# Altium schematic sheet Power Supply.SchDoc
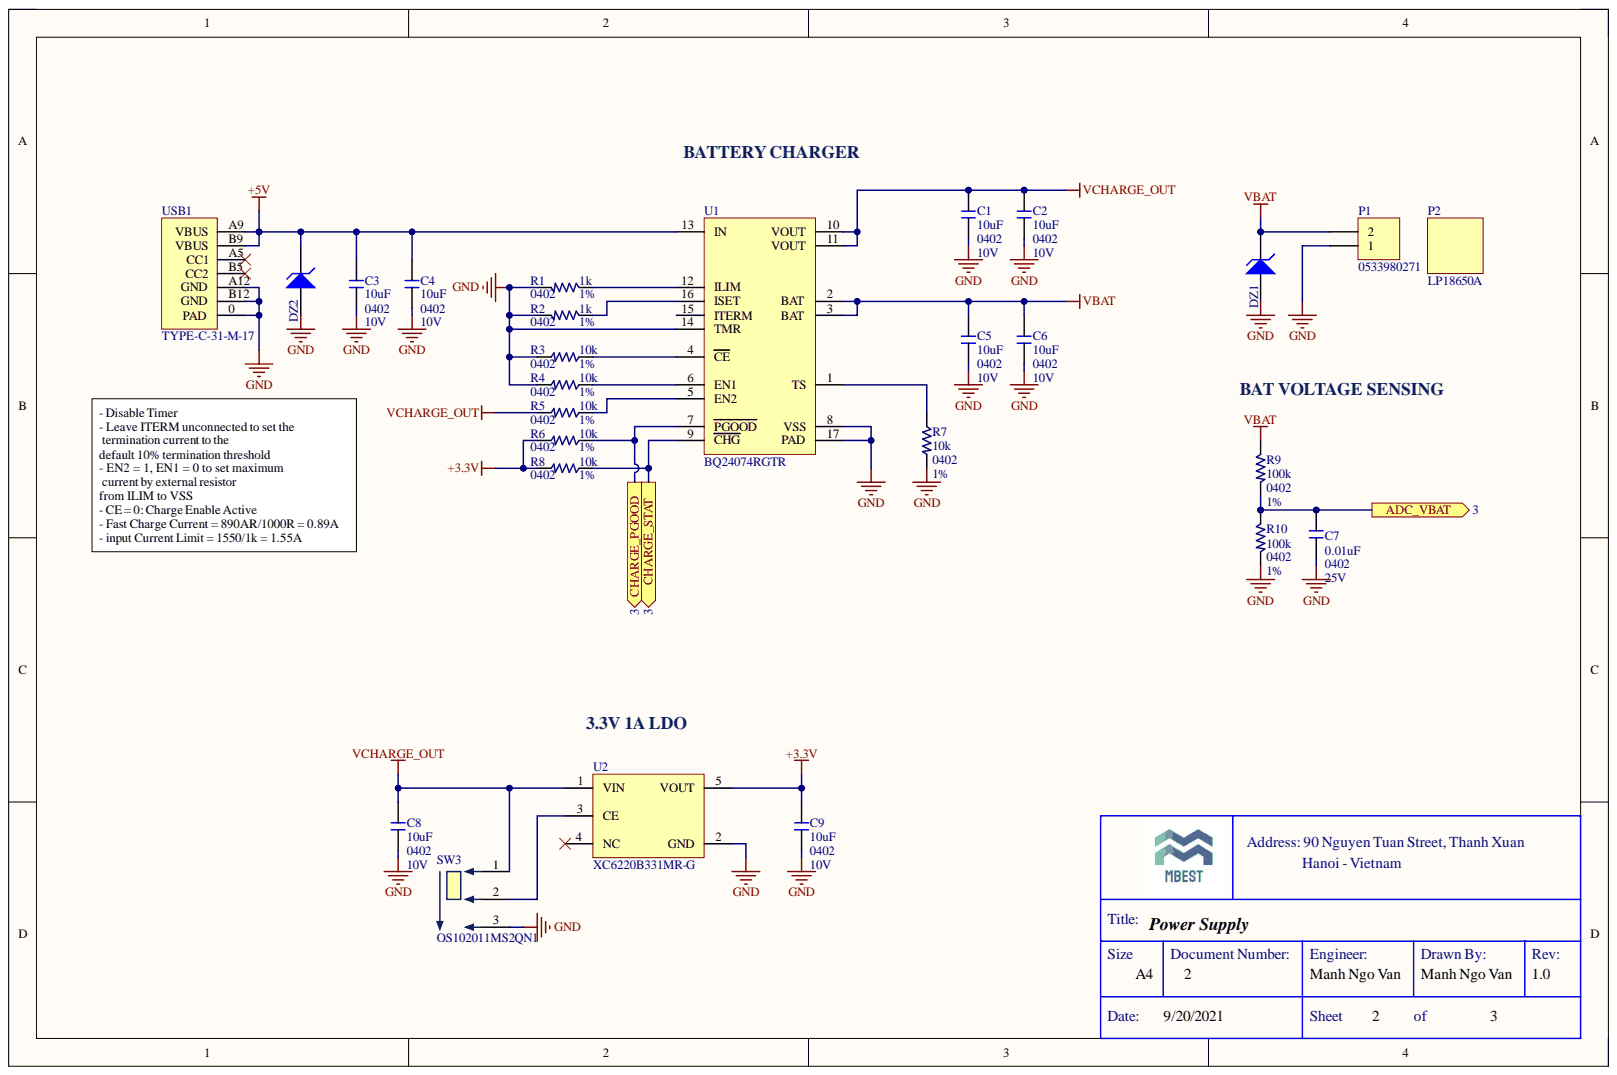
[diagram p0: Power Supply.SchDoc]

## Parts
C1  10uF  Case Code (Imperial): 0402  Voltage Rating: 10V  [C0402M]
C2  10uF  Case Code (Imperial): 0402  Voltage Rating: 10V  [C0402M]
C3  10uF  Case Code (Imperial): 0402  Voltage Rating: 10V  [C0402M]
C4  10uF  Case Code (Imperial): 0402  Voltage Rating: 10V  [C0402M]
C5  10uF  Case Code (Imperial): 0402  Voltage Rating: 10V  [C0402M]
C6  10uF  Case Code (Imperial): 0402  Voltage Rating: 10V  [C0402M]
C7  0.01uF  Case Code (Imperial): 0402  Voltage Rating: 25V  [C0402M]
C8  10uF  Case Code (Imperial): 0402  Voltage Rating: 10V  [C0402M]
C9  10uF  Case Code (Imperial): 0402  Voltage Rating: 10V  [C0402M]
DZ1  TPD1E10B06DPYR  [R0402M]  (value not drawn)
DZ2  TPD1E10B06DPYR  [R0402M]  (value not drawn)
P1  0533980271  [0533980271]  (no BOM)
P2  LP18650A  (mechanical)
R1  1k  Case Code (Imperial): 0402  Resistance Tolerance: 1%  [R0402M]
R2  1k  Case Code (Imperial): 0402  Resistance Tolerance: 1%  [R0402M]
R3  10k  Case Code (Imperial): 0402  Tolerance: 1%  [R0402M]
R4  10k  Case Code (Imperial): 0402  Tolerance: 1%  [R0402M]
R5  10k  Case Code (Imperial): 0402  Tolerance: 1%  [R0402M]
R6  10k  Case Code (Imperial): 0402  Tolerance: 1%  [R0402M]
R7  10k  Case Code (Imperial): 0402  Tolerance: 1%  [R0402M]
R8  10k  Case Code (Imperial): 0402  Tolerance: 1%  [R0402M]
R9  100k  Case Code (Imperial): 0402  Tolerance: 1%  [R0402M]
R10  100k  Case Code (Imperial): 0402  Tolerance: 1%  [R0402M]
SW3  OS102011MS2QN1  [OS102011MS2QN1]
U1  BQ24074RGTR  [QFN50P300X300X100_HS-17N]
U2  XC6220B331MR-G  [SOT95P280X145-5NP]
USB1  TYPE-C-31-M-17  [TYPE-C-31-M-17]

## Ports
ADC_VBAT, CHARGE_PGOOD, CHARGE_STAT

## Nets
+3.3V: C9.2 R6.1 R8.1 U2.5(VOUT)
+5V: C3.2 C4.2 DZ2.2 U1.13(IN) USB1.A9(VBUS) USB1.B9(VBUS)
GND: C1.1 C2.1 C3.1 C4.1 C5.1 C6.1 C7.1 C8.1 C9.1 DZ1.1 DZ2.1 P1.1 R1.1 R2.1 R3.1 R4.1 R7.1 R10.1 SW3.3 U1.8(VSS) U1.14(TMR) U1.17(PAD) U2.2(GND) USB1.0(PAD) USB1.A12(GND) USB1.B12(GND)
VBAT: C5.2 C6.2 DZ1.2 P1.2 R9.2 U1.2(BAT) U1.3(BAT)
VCHARGE_OUT: C1.2 C2.2 C8.2 R5.1 SW3.1 U1.10(VOUT) U1.11(VOUT) U2.1(VIN)
ADC_VBAT: C7.2 R9.1 R10.2 ; port ADC_VBAT
CHARGE_PGOOD: R6.2 U1.7(PGOOD) ; port CHARGE_PGOOD
CHARGE_STAT: R8.2 U1.9(CHG) ; port CHARGE_STAT
NetR1_2: R1.2 U1.12(ILIM)
NetR2_2: R2.2 U1.16(ISET)
NetR3_2: R3.2 U1.4(CE)
NetR4_2: R4.2 U1.6(EN1)
NetR5_2: R5.2 U1.5(EN2)
NetR7_2: R7.2 U1.1(TS)
NetSW3_2: SW3.2 U2.3(CE)

Not connected: U1 15(ITERM); U2 4(NC); USB1 A5(CC1) B5(CC2)

## Library data of the parts
C1-C6 C8 C9 = CAP_CER: CAP CER 10UF 10V X5R 0402; Manufacturer 1: Samsung; Manufacturer Part Number 1: CL05A106MP8NUB8; Mouting Technology: SMT; Tolerance: 20%; Capacitance: 10uF; Case Code (Metric): 1005; Case/Package: 0402; Category: Ceramic Capacitors; Component Kind: Standard; ComponentLink1Description: http://www.samsungsem.com/kr/support/product-search/mlcc/CL05A106MP8NUB8.jsp?src-supplier=Digi-Key; ComponentLink1URL: http://www.samsungsem.com/kr/support/product-search/mlcc/CL05A106MP8NUB8.jsp?src-supplier=Digi-Key; Length: 0.039inch; Library Name: CommonLib.SchLib; Max Operating Temperature: 85°C; Min Operating Temperature: -55°C; Mount: Surface Mount; Packaging: Tape and Reel; Pin: 2; Pricing 1: 1=0.37000, 10=0.25800, 50=0.18040, 100=0.15460, 500=0.11598, 1000=0.09793, 2500=0.09278 (USD); RoHS: Yes; RoHS Compliant: Yes; Stock 1: 5372550; Supplier 1: Digi-Key; Supplier Part Number 1: 1276-6830-6-ND; Thickness: 0.035inch; Width: 0.02inch
C7 = CAP_CER: CAP CER 10000PF 25V X7R 0402; Manufacturer: Samsung; Manufacturer Part Number: CL05B103KA5NNNC; Capacitance: 10nF; Tolerance: 10%; Case Code (Metric): 1005; Case/Package: 0402; Component Kind: Standard; ComponentLink1Description: https://datasheet.ciiva.com/11586/upy-gphc-x7r-6-3v-to-50v-8-11586326.pdf?src-supplier=Avnet; ComponentLink1URL: https://datasheet.ciiva.com/11586/upy-gphc-x7r-6-3v-to-50v-8-11586326.pdf?src-supplier=Avnet; ComponentLink2Description: https://datasheet.ciiva.com/17434/upy-gphc-x7r-6-3v-to-50v-8-17434572.pdf?src-supplier=Avnet+Europe; ComponentLink2URL: https://datasheet.ciiva.com/17434/upy-gphc-x7r-6-3v-to-50v-8-17434572.pdf?src-supplier=Avnet+Europe; ComponentLink3Description: https://datasheet.ciiva.com/15195/getdatasheetpartid-358612-15195635.pdf?src-supplier=Verical; ComponentLink3URL: https://datasheet.ciiva.com/15195/getdatasheetpartid-358612-15195635.pdf?src-supplier=Verical; ComponentLink4Description: https://datasheet.ciiva.com/16740/cl05b104kq5nnnc-16740683.pdf?src-supplier=Future+Electronics; ComponentLink4URL: https://datasheet.ciiva.com/16740/cl05b104kq5nnnc-16740683.pdf?src-supplier=Future+Electronics; Contact Plating: Tin; Depth: 0.5mm; Dielectric: X7R; Height: 0.5mm; Length: 1mm; Library Name: CommonLib.SchLib; Max Operating Temperature: 125°C; Min Operating Temperature: -55°C; Mount: Surface Mount; Package Quantity: 1; Packaging: Tape and Reel; Pricing 1: 1 = 0.10000, 10 = 0.01800, 50 = 0.00960, 100 = 0.00780, 500 = 0.00558, 1000 = 0.00439, 2500 = 0.00399, 5000 = 0.00367 (USD); Radiation Hardening: No; RoHS: Yes; Stock 1: 2714388; Supplier 1: Digi-Key; Supplier Part Number 1: 1276-1057-1-ND; Thickness: 0.022inch; Width: 0.02inch
DZ1 DZ2 = D Zener: TVS DIODE 5.5V 14V 2X1SON; Manufacturer: Texas Instruments; Breakdown Voltage: 6V; Capacitance: 12pF; Direction: Bidirectional; Element Configuration: Single; ESD Protection: Yes; Interface: GPIO; Leakage Current: 100nA; Number of Bidirectional Channels: 1; Peak Pulse Current: 5A; Peak Pulse Power: 90W; Power Line Protection: No; Test Current: 1mA; Case/Package: 0402; Clamping Voltage: 14V; Component Kind: Standard; ComponentLink1Description: https://datasheet.ciiva.com/26005/sllseb1b-26005840.pdf?src-supplier=Avnet+Europe; ComponentLink1URL: https://datasheet.ciiva.com/26005/sllseb1b-26005840.pdf?src-supplier=Avnet+Europe; ComponentLink2Description: https://datasheet.ciiva.com/46384/1877100-46384054.pdf?src-supplier=Element14; ComponentLink2URL: https://datasheet.ciiva.com/46384/1877100-46384054.pdf?src-supplier=Element14; ComponentLink3Description: https://datasheet.ciiva.com/14195/tpd1e10b06-14195178.pdf?src-supplier=Avnet; ComponentLink3URL: https://datasheet.ciiva.com/14195/tpd1e10b06-14195178.pdf?src-supplier=Avnet; ComponentLink4Description: https://datasheet.ciiva.com/22691/1877100-22691786.pdf?src-supplier=Element14; ComponentLink4URL: https://datasheet.ciiva.com/22691/1877100-22691786.pdf?src-supplier=Element14; ComponentLink5Description: https://datasheet.ciiva.com/2961/tpd1e10b06-2961245.pdf?src-supplier=Digi-Key; ComponentLink5URL: https://datasheet.ciiva.com/2961/tpd1e10b06-2961245.pdf?src-supplier=Digi-Key; ComponentLink6Description: https://datasheet.ciiva.com/2961/tpd1e10b06-2961246.pdf?src-supplier=Digi-Key; ComponentLink6URL: https://datasheet.ciiva.com/2961/tpd1e10b06-2961246.pdf?src-supplier=Digi-Key; ComponentLink7Description: https://datasheet.ciiva.com/6574/tpd1e10b06-6574654.pdf?src-supplier=Digi-Key; ComponentLink7URL: https://datasheet.ciiva.com/6574/tpd1e10b06-6574654.pdf?src-supplier=Digi-Key; Contact Plating: Gold; Height: 0.35mm; Lead Free: Lead Free; Length: 1.1mm; Library Name: CommonLib.SchLib; Manufacturer Part Number: TPD1E10B06DPYR; Max Operating Temperature: 125°C; Max Reverse Leakage Current: 100nA; Min Breakdown Voltage: 6V; Min Operating Temperature: -40°C; Number of Channels: 1; Number of Pins: 2; Package Quantity: 1; Packaging: Tape and Reel; Pricing 1: 1 = 0.45000, 10 = 0.29400, 100 = 0.16380, 500 = 0.10920, 1000 = 0.07560, 5000 = 0.07350 (USD); Radiation Hardening: No; REACH SVHC: No SVHC; Resistor Type: Zener; Reverse Standoff Voltage: 5.5V; RoHS: Yes; Signal Integrity: D Zener; Simulation: ZENER; Stock 1: 555750; Supplier 1: Digi-Key; Supplier Part Number 1: 296-30406-1-ND; Width: 0.7mm; Working Voltage: 5.5V
P1 = Header 2: CONN HEADER SMD 2POS 1.25MM; Manufacturer 1: Molex; Manufacturer Part Number 1: 53398-0271; Contact Resistance: 20mR; Current Rating: 1A; Gender: Male; Glow Wire Compliant: Non-compliant; Insulation Resistance: 100MR; Number of Circuits: 2; Voltage Rating: 125V; Category: Headers and Wire Housings; Color: Natural; ComponentLink1Description: https://datasheet.ciiva.com/7167/1626130-7167520.pdf?src-supplier=Newark; ComponentLink1URL: https://datasheet.ciiva.com/7167/1626130-7167520.pdf?src-supplier=Newark; ComponentLink2Description: https://datasheet.ciiva.com/7920/1841150-7920834.pdf?src-supplier=Element14; ComponentLink2URL: https://datasheet.ciiva.com/7920/1841150-7920834.pdf?src-supplier=Element14; ComponentLink3Description: https://datasheet.ciiva.com/14156/gf116833-116846-e-14156243.pdf?src-supplier=Distrelec; ComponentLink3URL: https://datasheet.ciiva.com/14156/gf116833-116846-e-14156243.pdf?src-supplier=Distrelec; ComponentLink4Description: https://datasheet.ciiva.com/36178/1932619-36178944.pdf?src-supplier=Jameco; ComponentLink4URL: https://datasheet.ciiva.com/36178/1932619-36178944.pdf?src-supplier=Jameco; ComponentLink5Description: https://datasheet.ciiva.com/28523/1759360-28523372.pdf?src-supplier=Element14; ComponentLink5URL: https://datasheet.ciiva.com/28523/1759360-28523372.pdf?src-supplier=Element14; ComponentLink6Description: https://datasheet.ciiva.com/9468/0533980271-pcb-headers-9468512.pdf?src-supplier=Avnet; ComponentLink6URL: https://datasheet.ciiva.com/9468/0533980271-pcb-headers-9468512.pdf?src-supplier=Avnet; ComponentLink7Description: https://datasheet.ciiva.com/30229/225140533980271-pcb-headers-30229043.pdf?src-supplier=Onlinecomponents.com; ComponentLink7URL: https://datasheet.ciiva.com/30229/225140533980271-pcb-headers-30229043.pdf?src-supplier=Onlinecomponents.com; ComponentLink8Description: https://datasheet.ciiva.com/5150/0533980271-pcb-headers-146564-5150549.pdf?src-supplier=Mouser; ComponentLink8URL: https://datasheet.ciiva.com/5150/0533980271-pcb-headers-146564-5150549.pdf?src-supplier=Mouser; ComponentLink9Description: https://datasheet.ciiva.com/11746/0900766b80ab946a-11746463.pdf?src-supplier=RSComponents; ComponentLink9URL: https://datasheet.ciiva.com/11746/0900766b80ab946a-11746463.pdf?src-supplier=RSComponents; ComponentLink10Description: https://datasheet.ciiva.com/22707/1867379-22707654.pdf?src-supplier=Element14; ComponentLink10URL: https://datasheet.ciiva.com/22707/1867379-22707654.pdf?src-supplier=Element14; ComponentLink11Description: https://datasheet.ciiva.com/22683/1626130-22683563.pdf?src-supplier=Element14; ComponentLink11URL: https://datasheet.ciiva.com/22683/1626130-22683563.pdf?src-supplier=Element14; ComponentLink12Description: https://datasheet.ciiva.com/16658/0533980271-pcb-headers-16658429.pdf?src-supplier=Avnet+Europe; ComponentLink12URL: https://datasheet.ciiva.com/16658/0533980271-pcb-headers-16658429.pdf?src-supplier=Avnet+Europe; ComponentLink13Description: https://datasheet.ciiva.com/18454/70090670-18454279.pdf?src-supplier=Allied+Electronics; ComponentLink13URL: https://datasheet.ciiva.com/18454/70090670-18454279.pdf?src-supplier=Allied+Electronics; Contact Material: Bronze,Brass,Tin; Contact Plating: Tin; Contact Type: Blade Pin; Depth: 4.2mm; Flammability Rating: UL94 V-0; Housing Color: Natural; Housing Material: Nylon; LatestRevisionDate: 17-Jul-2002; LatestRevisionNote: Re-released for DXP Platform.; Lead Free: Lead Free; Library Name: Miscellaneous Connectors.IntLib; Max Operating Temperature: 85°C; Min Operating Temperature: -40°C; Mount: Surface Mount; Number of Contacts: 2; Number of Positions: 2; Number of Rows: 1; Orientation: Vertical; Package Quantity: 1000; Packaging: Tape and Reel; Pitch: 1.25mm; Pricing 1: 1=0.53000, 10=0.44900, 100=0.34940 (USD); Radiation Hardening: No; REACH SVHC: Unknown; RoHS: Yes; RoHS Compliant: Yes; Series: PicoBlade; Signal Integrity: Connector; Stock 1: 417; Supplier 1: Digi-Key; Supplier Part Number 1: WM7606CT-ND; Termination: Solder; Voltage Rating (AC): 125V; Voltage Rating (DC): 125V; Working Voltage: 125V; Publisher: Altium Limited
P2 = ADDITION: 3.7V Rechargeable Lithium-ion Polymer Battery LP18650A+ 3500mAh With PCM and JST Connector; Manufacturer 1: Dongguan Juhe New Energy; ComponentLink1Description: Link; ComponentLink1URL: https://www.li-polymer-battery.com/3-7v-rechargeable-lithium-ion-polymer-battery-lp18650a-3500mah-with-pcm-and-jst-connector/page/5/?et_blog; Library Name: CommonLib.SchLib; Manufacturer Part Number 1: LP18650A
R1 R2 = RES_SMD: RES SMD 1K OHM 1% 1/16W 0402; Manufacturer 1: Yageo; Manufacturer Part Number 1: RC0402FR-071KL; Max Power Dissipation: 63mW; Mouting Technology: SMT; Power Rating: 62.5mW; Resistance: 1kR; Temperature Coefficient: 100ppm/°C; Voltage Rating: 50V; Case Code (Metric): 1005; Case/Package: 0402; Category: Chip SMD Resistors; Component Kind: Standard; ComponentLink1Description: https://datasheet.ciiva.com/11819/0900766b80c66297-11819477.pdf?src-supplier=RSComponents; ComponentLink1URL: https://datasheet.ciiva.com/11819/0900766b80c66297-11819477.pdf?src-supplier=RSComponents; ComponentLink2Description: https://datasheet.ciiva.com/7983/1018594-7983502.pdf?src-supplier=Element14; ComponentLink2URL: https://datasheet.ciiva.com/7983/1018594-7983502.pdf?src-supplier=Element14; ComponentLink3Description: https://datasheet.ciiva.com/22747/1018594-22747513.pdf?src-supplier=Element14; ComponentLink3URL: https://datasheet.ciiva.com/22747/1018594-22747513.pdf?src-supplier=Element14; ComponentLink4Description: https://datasheet.ciiva.com/12666/pyu-rc0402-51-rohs-l-6-r-12666741.pdf?src-supplier=Mouser; ComponentLink4URL: https://datasheet.ciiva.com/12666/pyu-rc0402-51-rohs-l-6-r-12666741.pdf?src-supplier=Mouser; ComponentLink5Description: https://datasheet.ciiva.com/7849/1018594-7849869.pdf?src-supplier=Element14; ComponentLink5URL: https://datasheet.ciiva.com/7849/1018594-7849869.pdf?src-supplier=Element14; ComponentLink6Description: https://datasheet.ciiva.com/7849/1018594-7849871.pdf?src-supplier=Element14; ComponentLink6URL: https://datasheet.ciiva.com/7849/1018594-7849871.pdf?src-supplier=Element14; ComponentLink7Description: https://datasheet.ciiva.com/17063/pyu-rc0402-51-rohs-l-6-r-17063193.pdf?src-supplier=Future+Electronics; ComponentLink7URL: https://datasheet.ciiva.com/17063/pyu-rc0402-51-rohs-l-6-r-17063193.pdf?src-supplier=Future+Electronics; Construction: Rectangular; Depth: 0.5mm; Features: Moisture Resistant; Height: 0.32mm; Lead Free: Lead Free; Length: 1mm; Library Name: CommonLib.SchLib; Max Operating Temperature: 155°C; Military Standard: Not; Min Operating Temperature: -55°C; Number of Pins: 2; Number of Terminations: 2; Packaging: Tape and Reel; Pin: 2; Pricing 1: 1=0.10000, 10=0.01600, 100=0.00660, 1000=0.00299, 2500=0.00259, 5000=0.00214 (USD); REACH SVHC: Unknown; Resistor Type: Thick Film; RoHS: Yes; RoHS Compliant: Yes; Series: RC; Size Code: 0402; Supplier 1: Digi-Key; Supplier Part Number 1: 311-1.00KLRCT-ND; Technology: THICK FILM; Termination: SMD/SMT; Tolerance: 1%; Voltage Rating (DC): 50V; Width: 0.5mm
R3-R8 = RES_SMD: RES SMD 10K OHM 1% 1/16W 0402; Manufacturer: Yageo; Manufacturer Part Number: RC0402FR-0710KL; Power Rating: 62.5mW; Resistance: 10kR; Temperature Coefficient: 100ppm/°C; Voltage Rating: 50V; Case Code (Metric): 1005; Case/Package: 0402; Component Kind: Standard; ComponentLink1Description: https://datasheet.ciiva.com/7660/38568-7660787.pdf?src-supplier=Element14; ComponentLink1URL: https://datasheet.ciiva.com/7660/38568-7660787.pdf?src-supplier=Element14; ComponentLink2Description: https://datasheet.ciiva.com/7849/1784430-7849813.pdf?src-supplier=Element14; ComponentLink2URL: https://datasheet.ciiva.com/7849/1784430-7849813.pdf?src-supplier=Element14; ComponentLink3Description: https://datasheet.ciiva.com/7849/1686128-7849814.pdf?src-supplier=Element14; ComponentLink3URL: https://datasheet.ciiva.com/7849/1686128-7849814.pdf?src-supplier=Element14; ComponentLink4Description: https://datasheet.ciiva.com/7849/1784430-7849815.pdf?src-supplier=Element14; ComponentLink4URL: https://datasheet.ciiva.com/7849/1784430-7849815.pdf?src-supplier=Element14; ComponentLink5Description: https://datasheet.ciiva.com/22747/1018594-22747512.pdf?src-supplier=Element14; ComponentLink5URL: https://datasheet.ciiva.com/22747/1018594-22747512.pdf?src-supplier=Element14; ComponentLink6Description: https://datasheet.ciiva.com/12667/pyu-rc0402-51-rohs-l-6-r-12667067.pdf?src-supplier=Mouser; ComponentLink6URL: https://datasheet.ciiva.com/12667/pyu-rc0402-51-rohs-l-6-r-12667067.pdf?src-supplier=Mouser; ComponentLink7Description: https://datasheet.ciiva.com/7983/1018594-7983486.pdf?src-supplier=Element14; ComponentLink7URL: https://datasheet.ciiva.com/7983/1018594-7983486.pdf?src-supplier=Element14; Composition: Thick Film; Depth: 0.5mm; Height: 0.32mm; Lead Free: Lead Free; Lead Pitch: 0.2mm; Length: 1mm; Library Name: CommonLib.SchLib; Max Operating Temperature: 155°C; Military Standard: Not; Min Operating Temperature: -55°C; Number of Pins: 2; Number of Terminations: 2; Packaging: Tape and Reel; Pricing 1: 10000 = 0.001630, 30000 = 0.001440, 50000 = 0.001320, 100000 = 0.001290 (USD); REACH SVHC: No SVHC; RoHS: Yes; Stock 1: 58760000; Supplier 1: Digi-Key; Supplier Part Number 1: 311-10.0KLRTR-ND; Termination: SMD/SMT; Voltage Rating (DC): 50V; Width: 0.5mm; Working Voltage: 50V
R9 R10 = RES_SMD: RES SMD 100K OHM 1% 1/16W 0402; Manufacturer: Yageo; Manufacturer Part Number: RC0402FR-07100KL; Max Power Dissipation: 63mW; Resistance: 100kR; Temperature Coefficient: 100ppm/°C; Voltage Rating: 50V; Case Code (Metric): 1005; Case/Package: 0402; Component Kind: Standard; ComponentLink1Description: https://datasheet.ciiva.com/11808/0900766b80f96399-11808107.pdf?src-supplier=RSComponents; ComponentLink1URL: https://datasheet.ciiva.com/11808/0900766b80f96399-11808107.pdf?src-supplier=RSComponents; ComponentLink2Description: https://datasheet.ciiva.com/7983/443971-7983593.pdf?src-supplier=Element14; ComponentLink2URL: https://datasheet.ciiva.com/7983/443971-7983593.pdf?src-supplier=Element14; ComponentLink3Description: https://datasheet.ciiva.com/46440/1868787-46440889.pdf?src-supplier=Element14; ComponentLink3URL: https://datasheet.ciiva.com/46440/1868787-46440889.pdf?src-supplier=Element14; ComponentLink4Description: https://datasheet.ciiva.com/7746/1738695-7746234.pdf?src-supplier=Element14; ComponentLink4URL: https://datasheet.ciiva.com/7746/1738695-7746234.pdf?src-supplier=Element14; ComponentLink5Description: https://datasheet.ciiva.com/7746/1738695-7746235.pdf?src-supplier=Element14; ComponentLink5URL: https://datasheet.ciiva.com/7746/1738695-7746235.pdf?src-supplier=Element14; ComponentLink6Description: https://datasheet.ciiva.com/22747/443971-22747516.pdf?src-supplier=Element14; ComponentLink6URL: https://datasheet.ciiva.com/22747/443971-22747516.pdf?src-supplier=Element14; ComponentLink7Description: https://datasheet.ciiva.com/7850/1018594-7850133.pdf?src-supplier=Element14; ComponentLink7URL: https://datasheet.ciiva.com/7850/1018594-7850133.pdf?src-supplier=Element14; ComponentLink8Description: https://datasheet.ciiva.com/2491/pyu-r-int-thick-7-2491207.pdf?src-supplier=Digi-Key; ComponentLink8URL: https://datasheet.ciiva.com/2491/pyu-r-int-thick-7-2491207.pdf?src-supplier=Digi-Key; ComponentLink9Description: https://datasheet.ciiva.com/2491/pyu-r-int-thick-7-2491208.pdf?src-supplier=Digi-Key; ComponentLink9URL: https://datasheet.ciiva.com/2491/pyu-r-int-thick-7-2491208.pdf?src-supplier=Digi-Key; ComponentLink10Description: https://datasheet.ciiva.com/2491/pyu-r-int-thick-7-2491209.pdf?src-supplier=Digi-Key; ComponentLink10URL: https://datasheet.ciiva.com/2491/pyu-r-int-thick-7-2491209.pdf?src-supplier=Digi-Key; ComponentLink11Description: https://datasheet.ciiva.com/17052/pyu-rc0402-51-rohs-l-6-r-17052137.pdf?src-supplier=Future+Electronics; ComponentLink11URL: https://datasheet.ciiva.com/17052/pyu-rc0402-51-rohs-l-6-r-17052137.pdf?src-supplier=Future+Electronics; ComponentLink12Description: https://datasheet.ciiva.com/12668/pyu-rc0402-51-rohs-l-6-r-12668218.pdf?src-supplier=Mouser; ComponentLink12URL: https://datasheet.ciiva.com/12668/pyu-rc0402-51-rohs-l-6-r-12668218.pdf?src-supplier=Mouser; ComponentLink13Description: https://datasheet.ciiva.com/46394/1018594-46394941.pdf?src-supplier=Element14; ComponentLink13URL: https://datasheet.ciiva.com/46394/1018594-46394941.pdf?src-supplier=Element14; Depth: 0.5mm; Features: Moisture Resistant; Height: 0.016inch; Lead Free: Lead Free; Length: 1mm; Library Name: BLE1.SchLib; Max Operating Temperature: 155°C; Military Standard: Not; Min Operating Temperature: -55°C; Number of Terminations: 2; Package Quantity: 1; Packaging: Tape and Reel; Power Rating: 63mW; Pricing 1: 1 = 0.10000, 10 = 0.01200, 100 = 0.00480, 1000 = 0.00215, 2500 = 0.00186, 5000 = 0.00154 (USD); REACH SVHC: Unknown; Resistor Type: Thick Film; RoHS: Yes; Stock 1: 3850330; Supplier 1: Digi-Key; Supplier Part Number 1: 311-100KJRCT-ND; Termination: SMD/SMT; Width: 0.02inch
SW3 = JS202011JAQN: Slide; Manufacturer 1: ITT C&K; Actuator Color: Black; Actuator Length: 4mm; Actuator Material: Polyoxymethylene,Acetal,Polyacetal; Actuator Type: Standard; Contact Current Rating: 100mA; Contact Resistance: 20mR; Contact Style: Non-Shorting; Electrical Life: 10000Cycles; Insulation Resistance: 100MR; Max Voltage Rating (DC): 12V; Switch Function: On-On; Switch Travel: 2mm; Throw Configuration: SPDT; Category: Slide Switches; Component Kind: Standard; ComponentLink1Description: https://datasheet.ciiva.com/30466/0900766b81263f61-30466806.pdf?src-supplier=RSComponents; ComponentLink1URL: https://datasheet.ciiva.com/30466/0900766b81263f61-30466806.pdf?src-supplier=RSComponents; ComponentLink2Description: https://datasheet.ciiva.com/16298/os102011ms2qn1-16298526.pdf?src-supplier=Future+Electronics; ComponentLink2URL: https://datasheet.ciiva.com/16298/os102011ms2qn1-16298526.pdf?src-supplier=Future+Electronics; ComponentLink3Description: https://datasheet.ciiva.com/2889/os-19jan15-2889173.pdf?src-supplier=Digi-Key; ComponentLink3URL: https://datasheet.ciiva.com/2889/os-19jan15-2889173.pdf?src-supplier=Digi-Key; ComponentLink4Description: https://datasheet.ciiva.com/1699/os-19jan15-1699479.pdf?src-supplier=Newark; ComponentLink4URL: https://datasheet.ciiva.com/1699/os-19jan15-1699479.pdf?src-supplier=Newark; ComponentLink5Description: https://datasheet.ciiva.com/18147/getdatasheetpartid-320490-18147210.pdf?src-supplier=Verical; ComponentLink5URL: https://datasheet.ciiva.com/18147/getdatasheetpartid-320490-18147210.pdf?src-supplier=Verical; ComponentLink6Description: https://datasheet.ciiva.com/16997/70128245-16997771.pdf?src-supplier=Allied+Electronics; ComponentLink6URL: https://datasheet.ciiva.com/16997/70128245-16997771.pdf?src-supplier=Allied+Electronics; ComponentLink7Description: https://datasheet.ciiva.com/26290/os-11mar13-26290652.pdf?src-supplier=Onlinecomponents.com; ComponentLink7URL: https://datasheet.ciiva.com/26290/os-11mar13-26290652.pdf?src-supplier=Onlinecomponents.com; ComponentLink8Description: https://datasheet.ciiva.com/1532/1683358-1532308.pdf?src-supplier=Element14; ComponentLink8URL: https://datasheet.ciiva.com/1532/1683358-1532308.pdf?src-supplier=Element14; Contact Material: Copper Alloy,Brass,Silver; Contact Plating: Silver,Gold; Current Rating: 100mA; Depth: 4.3mm; Housing Material: Resin; Lead Free: Lead Free; Length: 8.6mm; Manufacturer Part Number 1: OS102011MS2QN1; Max Current Rating: 100mA; Max Operating Temperature: 70°C; Mechanical Life: 10000Cycles; Min Operating Temperature: -30°C; Mount: Through Hole,PCB; Orientation: Vertical; Package Quantity: 300; Packaging: Bulk; Pricing 1: 1=0.51000, 10=0.49300, 25=0.47520, 100=0.41110, 250=0.37452, 500=0.34712, 1000=0.31059, 2500=0.29415, 5000=0.27222 (USD); Radiation Hardening: No; REACH SVHC: No SVHC; RoHS: Yes; RoHS Compliant: Yes; Series: OS; Stock 1: 34195; Supplier 1: Digi-Key; Supplier Part Number 1: CKN9565-ND; Termination: PC Pins; Voltage Rating (DC): 12V; Library Name: Poskast.SchLib
U1 = BQ24074RGTR: IC BATT CHG LI-ION 1CELL 16QFN; Manufacturer 1: Texas Instruments; Battery Chemistry: LITHIUM-ION; Battery Pack Voltage: 4.2V; Fault Protection: Over Voltage,Over Temperature,Short Circuit,Reverse Current; Interface: USB; Max Charge Current: 1.5A; Max Supply Voltage: 10.2V; Min Input Voltage: 4.3V; Min Supply Voltage: 4.35V; Number of Cells: 1; Case/Package: VQFN; Category: Battery Management; Component Kind: Standard; ComponentLink1Description: https://datasheet.ciiva.com/1932/bq24074-1932360.pdf?src-supplier=Digi-Key; ComponentLink1URL: https://datasheet.ciiva.com/1932/bq24074-1932360.pdf?src-supplier=Digi-Key; ComponentLink2Description: https://datasheet.ciiva.com/26811/getdatasheetpartid-357714-26811766.pdf?src-supplier=Verical; ComponentLink2URL: https://datasheet.ciiva.com/26811/getdatasheetpartid-357714-26811766.pdf?src-supplier=Verical; ComponentLink3Description: https://datasheet.ciiva.com/3214/bq24079-3214039.pdf?src-supplier=Avnet; ComponentLink3URL: https://datasheet.ciiva.com/3214/bq24079-3214039.pdf?src-supplier=Avnet; ComponentLink4Description: https://datasheet.ciiva.com/26109/bq24079-26109321.pdf?src-supplier=Avnet+Europe; ComponentLink4URL: https://datasheet.ciiva.com/26109/bq24079-26109321.pdf?src-supplier=Avnet+Europe; Contact Plating: Gold; Lead Free: Lead Free; Library Name: SmartHome.SchLib; Manufacturer Part Number 1: BQ24074RGTR; Max Operating Temperature: 125°C; Min Operating Temperature: -40°C; Number of Pins: 16; Output Current: 1.5A; Output Voltage: 4.2V; Package Quantity: 3000; Packaging: Tape and Reel; Pricing 1: 1=2.29000, 10=2.07000, 50=1.95000, 100=1.66000, 1000=1.13000, 10000=1.06000 (USD); Radiation Hardening: No; RoHS: Yes; RoHS Compliant: Yes; Series: -; Stock 1: 15; Supplier 1: Mouser; Supplier Part Number 1: 595-BQ24074RGTR; Weight: 0.000808oz
U2 = XC6220B331MR-G: IC REG LINEAR 3.3V 1A SOT25; Manufacturer: Torex; Dropout Voltage: 655mV; Max Input Voltage: 6V; Min Current Limit: 1.005A; Min Input Voltage: 1.6V; Number of Regulators: 1; Output Type: Fixed; Case/Package: SOT-25; Component Kind: Standard; ComponentLink1Description: https://datasheet.ciiva.com/2040/xc6220-2040200.pdf?src-supplier=Digi-Key; ComponentLink1URL: https://datasheet.ciiva.com/2040/xc6220-2040200.pdf?src-supplier=Digi-Key; ComponentLink2Description: https://datasheet.ciiva.com/2040/xc6220-2040201.pdf?src-supplier=Digi-Key; ComponentLink2URL: https://datasheet.ciiva.com/2040/xc6220-2040201.pdf?src-supplier=Digi-Key; ComponentLink3Description: https://datasheet.ciiva.com/2040/xc6220-2040202.pdf?src-supplier=Digi-Key; ComponentLink3URL: https://datasheet.ciiva.com/2040/xc6220-2040202.pdf?src-supplier=Digi-Key; ComponentLink4Description: https://datasheet.ciiva.com/7992/610888-7992813.pdf?src-supplier=Element14; ComponentLink4URL: https://datasheet.ciiva.com/7992/610888-7992813.pdf?src-supplier=Element14; ComponentLink5Description: https://datasheet.ciiva.com/5755/610888-5755886.pdf?src-supplier=Farnell; ComponentLink5URL: https://datasheet.ciiva.com/5755/610888-5755886.pdf?src-supplier=Farnell; Library Name: SmartHome.SchLib; Manufacturer Part Number: XC6220B331MR-G; Max Operating Temperature: 85°C; Min Operating Temperature: -40°C; Mount: Surface Mount; Nominal Output Voltage: 3.3V; Number of Pins: 5; Output Current: 1A; Output Voltage: 3.3V; Package Quantity: 1; Packaging: Tape and Reel; Pricing 1: 1 = 0.91000, 10 = 0.81100, 25 = 0.77000, 100 = 0.59130, 250 = 0.52252, 500 = 0.49500, 1000 = 0.38500 (USD); REACH SVHC: No SVHC; RoHS: Yes; Stock 1: 13360; Supplier 1: Digi-Key; Supplier Part Number 1: 893-1133-1-ND
USB1 = TYPE-C-31-M-17: USB - Type C Female 6 USB Connectors RoHS; Manufacturer 1: Korean Hroparts Elec; Component Kind: Standard; Manufacturer Part Number 1: TYPE-C-31-M-17; Library Name: Galvanic.SchLib
